# Altium schematic sheet Power.SchDoc
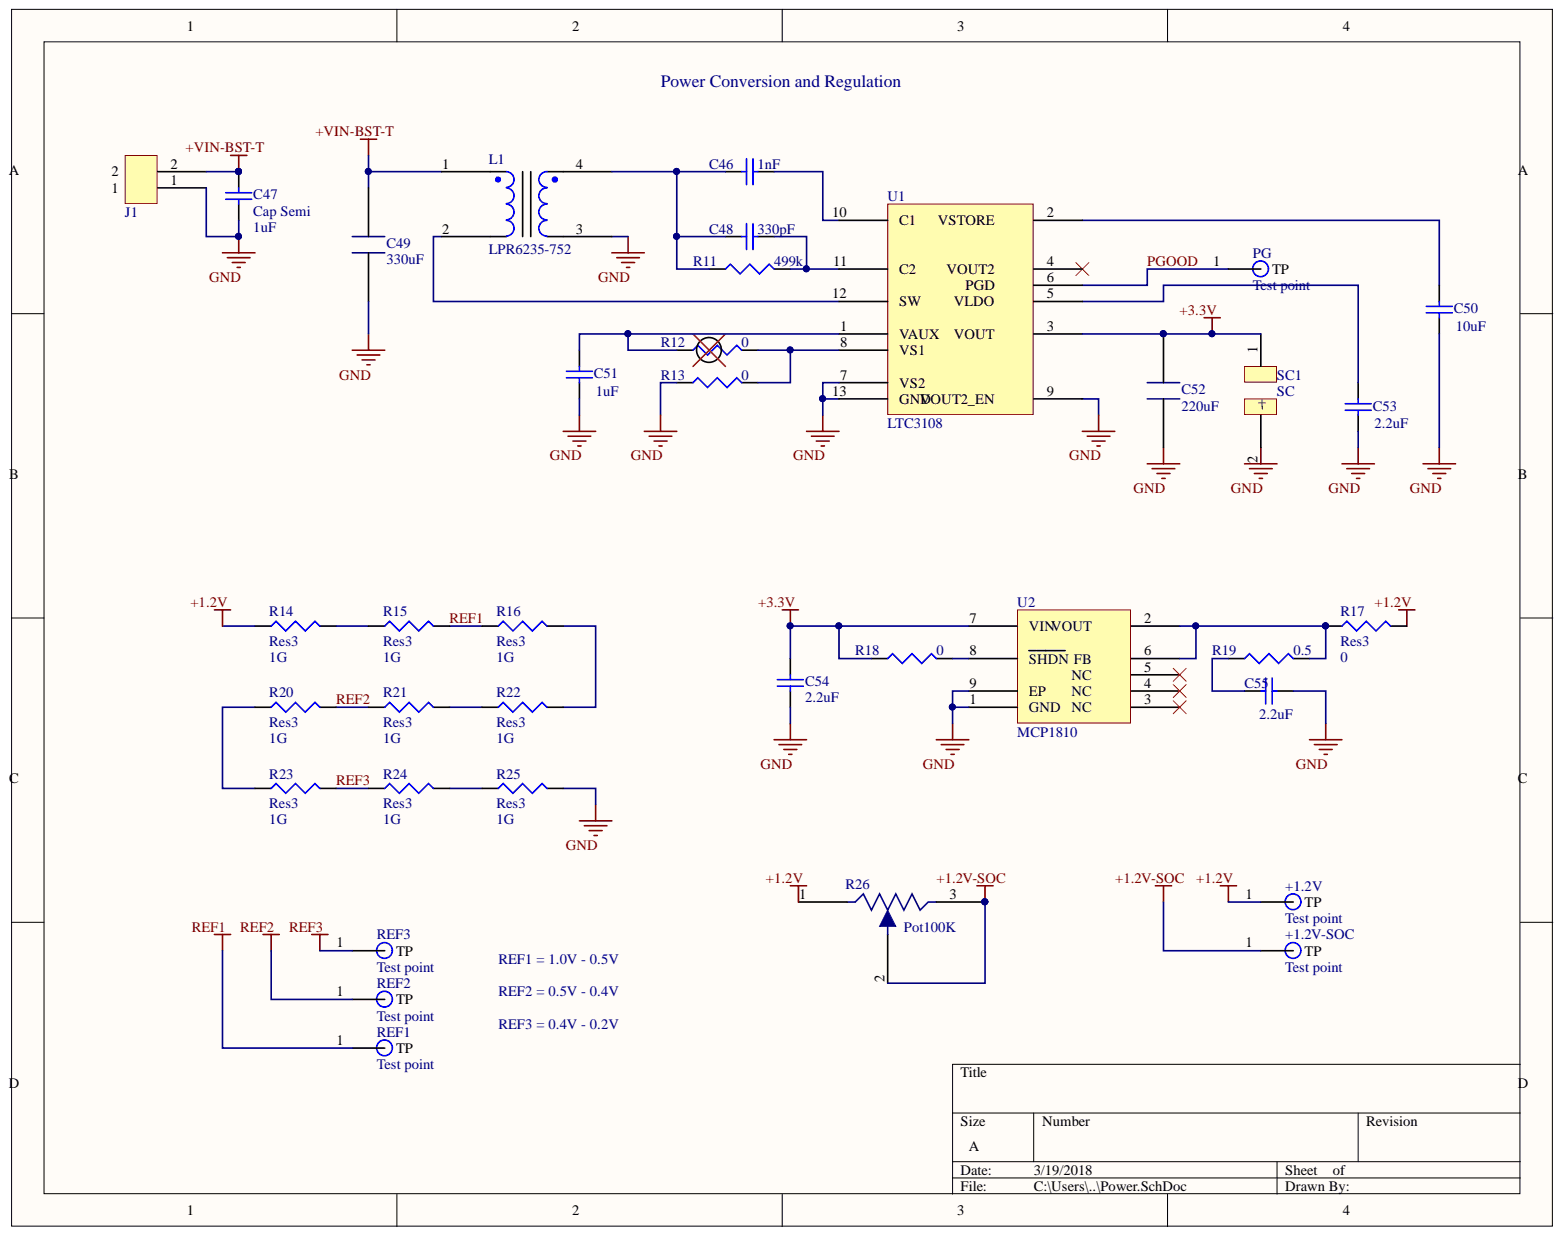
[diagram p0: Power.SchDoc]

## Parts
+1.2V  Test point  [TP]
+1.2V-SOC  Test point  [TP]
C46  value 1nF  [0402]  (hidden comment: Cap Semi)
C47  Cap Semi  value 1uF  [0402]
C48  value 330pF  [0402]  (hidden comment: Cap Semi)
C49  330uF  [CAPCP7343X43NEW]
C50  value 10uF  [0402]  (hidden comment: Cap Semi)
C51  value 1uF  [0402]  (hidden comment: Cap Semi)
C52  220uF  [CAPCP7343X43NEW]
C53  value 2.2uF  [0402]  (hidden comment: Cap Semi)
C54  value 2.2uF  [0402]  (hidden comment: Cap Semi)
C55  value 2.2uF  [0402]  (hidden comment: Cap Semi)
J1  MolexPicoLock  [5037630291_MolexPicoLock]  (value not drawn)
L1  LPR6235-752  [LPR6235-752]
PG  Test point  [TP]
R11  value 499k  [0402]  (hidden comment: Res3)
R12  value 0  [0402]  (hidden comment: Res3)
R13  value 0  [0402]  (hidden comment: Res3)
R14  Res3  value 1G  [6-0805_N]
R15  Res3  value 1G  [6-0805_N]
R16  Res3  value 1G  [6-0805_N]
R17  Res3  value 0  [0402]
R18  value 0  [0402]  (hidden comment: Res3)
R19  value 0.5  [0402]  (hidden comment: Res3)
R20  Res3  value 1G  [6-0805_N]
R21  Res3  value 1G  [6-0805_N]
R22  Res3  value 1G  [6-0805_N]
R23  Res3  value 1G  [6-0805_N]
R24  Res3  value 1G  [6-0805_N]
R25  Res3  value 1G  [6-0805_N]
R26  Pot100K  [Pot100K]
REF1  Test point  [TP]
REF2  Test point  [TP]
REF3  Test point  [TP]
SC1  SC  [SocketBattCR2032]
U1  LTC3108  [QFN0P300X400X80_HS-13M]
U2  MCP1810  [MCP1810]

## Nets
+1.2V: +1.2V.1 R14.1 R17.2 R26.1
+1.2V-SOC: +1.2V-SOC.1 R26.2 R26.3
+3.3V: C52.1 C54.2 R18.1 SC1.1 U1.3 U2.7(VIN)
+VIN-BST-T: C47.1 C49.1 J1.2 L1.1
GND: C47.2 C49.2 C50.1 C51.1 C52.2 C53.1 C54.1 C55.1 J1.1 L1.3 R13.1 R25.2 SC1.2 U1.7(VS2) U1.9 U1.13(GND) U2.1(GND) U2.9(EP)
PGOOD: PG.1 U1.6
REF1: R15.2 R16.1 REF1.1
REF2: R20.2 R21.1 REF2.1
REF3: R23.2 R24.1 REF3.1
NetC46_1: C46.1 C48.1 L1.4 R11.1
NetC46_2: C46.2 U1.10(C1)
NetC48_2: C48.2 R11.2 U1.11(C2)
NetC50_2: C50.2 U1.2
NetC51_2: C51.2 R12.1 U1.1(VAUX)
NetC53_2: C53.2 U1.5
NetC55_2: C55.2 R19.1
NetL1_2: L1.2 U1.12(SW)
NetR12_2: R12.2 R13.2 U1.8(VS1)
NetR14_2: R14.2 R15.1
NetR16_2: R16.2 R22.2
NetR17_1: R17.1 R19.2 U2.2 U2.6
NetR18_2: R18.2 U2.8
NetR20_1: R20.1 R23.1
NetR21_2: R21.2 R22.1
NetR24_2: R24.2 R25.1

Not connected: U1 4; U2 3 4 5

## Library data of the parts
C46-C48 C50 C51 C53-C55 = Cap Semi: Capacitor (Semiconductor SIM Model); Component Kind: Standard; LatestRevisionDate: 29-May-2009; LatestRevisionNote: IPC-7351 Footprint Added.; Library Name: Miscellaneous Devices.IntLib; PackageDescription: Chip Capacitor; PackageReference: C1206; Published: 8-Jun-2000; Signal Integrity: Cap Semi; Simulation: CAP; Publisher: Altium Limited
C49 C52 = BoostCap: Component Kind: Standard; Library Name: ASSIST.IntLib
J1 = MolexPicoLock: Molex Pico Lock 2 pin; Component Kind: Standard; Library Name: INERTIA.IntLib
R11-R25 = Res3: Resistor; Component Kind: Standard; LatestRevisionDate: 29-May-2009; LatestRevisionNote: IPC-7351 Footprint Added.; Library Name: Miscellaneous Devices.IntLib; PackageDescription: Chip Resistor; PackageReference: J1-0603; Published: 8-Jun-2000; Signal Integrity: Res; Simulation: RESISTOR; Publisher: Altium Limited
R26 = Pot100K: EVM-2WSX80B15 100K Trimpot SMD; Component Kind: Standard; Library Name: INERTIA.IntLib
SC1 = Soket Battery CR2032: Socket Battery CR2032; Component Kind: Standard; Library Name: INERTIA.IntLib
U2 = MCP1810: Ultra-low Power LDO; Component Kind: Standard; Library Name: INERTIA.IntLib
+1.2V +1.2V-SOC PG REF1-REF3 = Test point: Component Kind: Standard; Library Name: INERTIA.IntLib
L1 = LPR6235-752: Component Kind: Standard; Description: LPR6235-752; Library Name: INERTIA.IntLib
U1 = LTC3108: Component Kind: Standard; Description: LTC3108; Library Name: INERTIA.IntLib
